# Altium schematic sheet RB_Conn.SchDoc(RB_Conn)
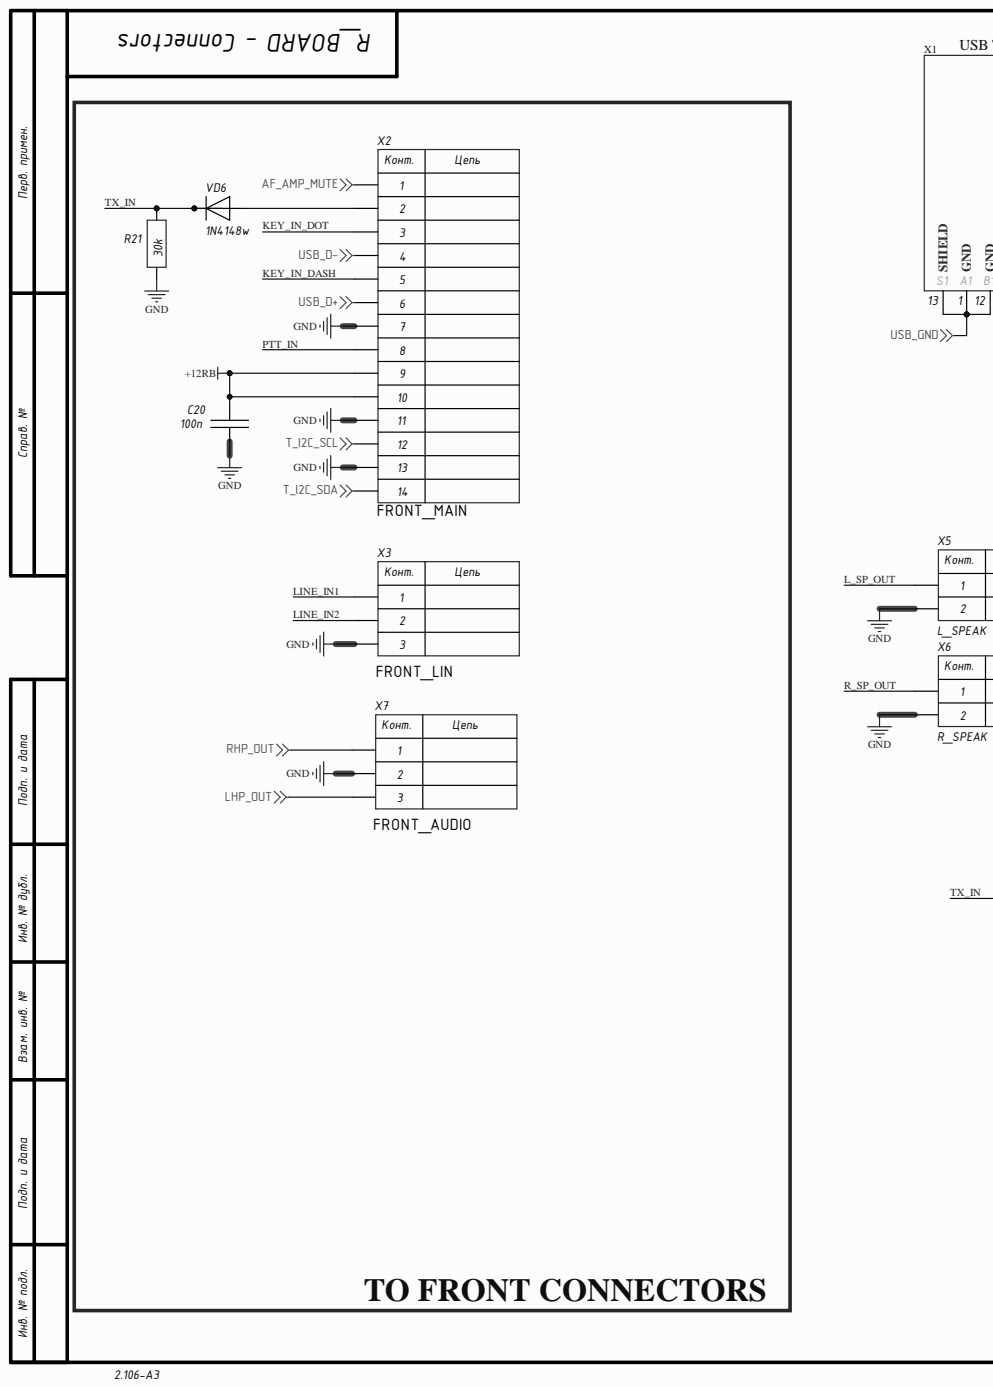
[diagram p0: RB_Conn.SchDoc, left]
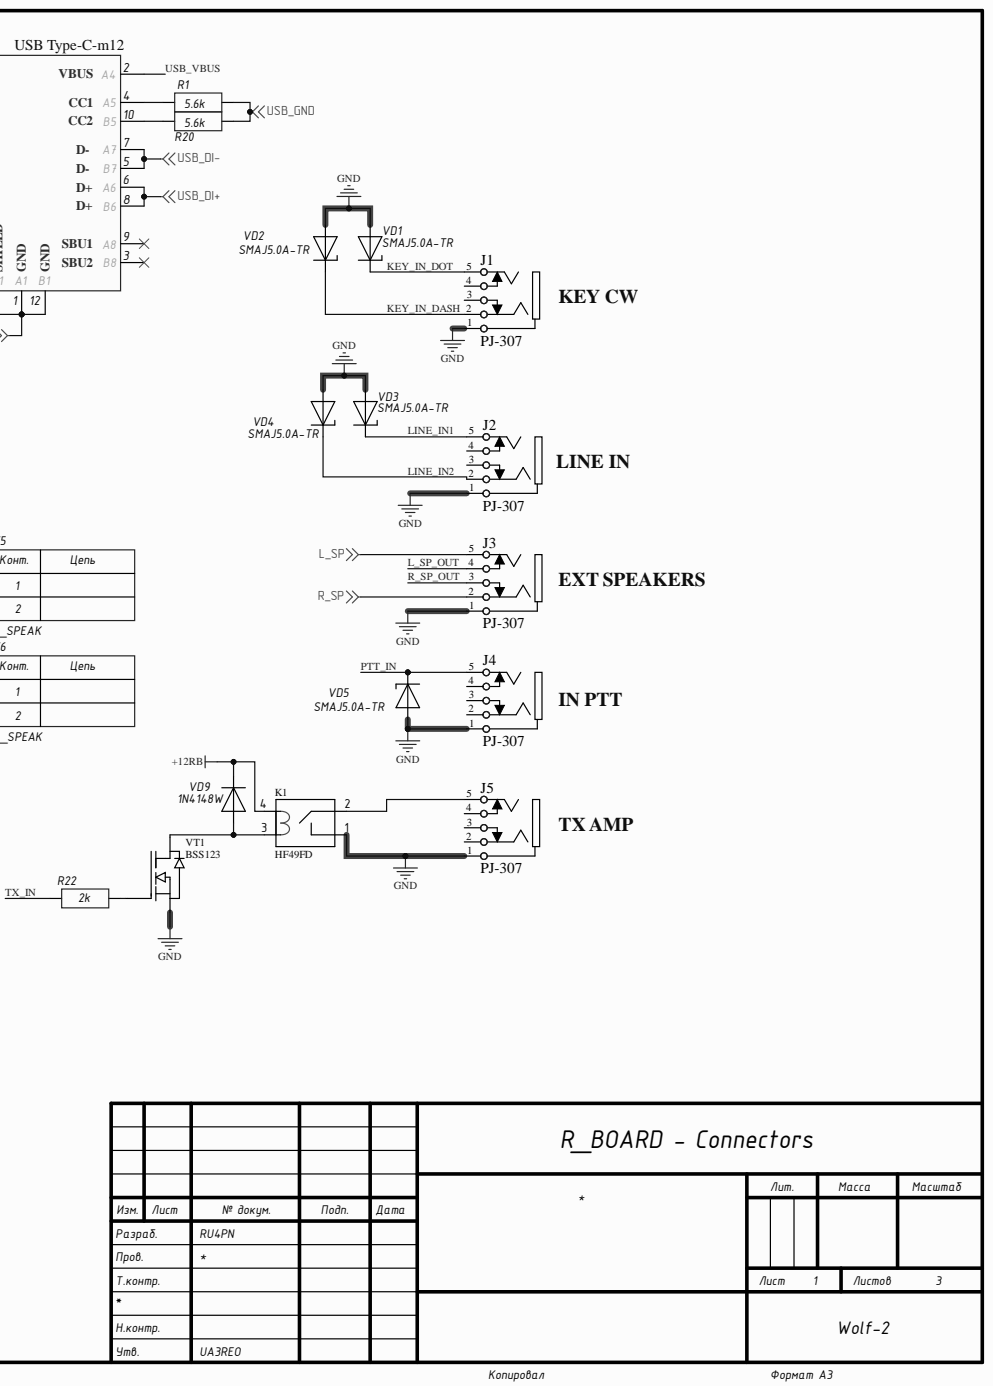
[diagram p1: RB_Conn.SchDoc, right]

## Parts
C20  100n  [CH0805C]
J1  PJ-307  [PJ-307]
J2  PJ-307  [PJ-307]
J3  PJ-307  [PJ-307]
J4  PJ-307  [PJ-307]
J5  PJ-307  [PJ-307]
K1  HF49FD  [HF49FD]
R1  5.6k  [CH0805R]
R20  5.6k  [CH0805R]
R21  30k  [CH0805R]
R22  2k  [CH0805R]
VD1  SMAJ5.0A-TR  [SMA]
VD2  SMAJ5.0A-TR  [SMA]
VD3  SMAJ5.0A-TR  [SMA]
VD4  SMAJ5.0A-TR  [SMA]
VD5  SMAJ5.0A-TR  [SMA]
VD6  1N4148w  [SOD323]
VD9  1N4148W  [SOD123]
VT1  BSS123  [SOT23_132]
X1  USB Type-C-m12  [PCBComponent_1]
X2  FRONT_MAIN  [CON: IDC-14MS]
X3  FRONT_LIN  [CON: CWF-3]
X5  L_SPEAK  [CON: PLS-2]
X6  R_SPEAK  [CON: PLS-2]
X7  FRONT_AUDIO  [CON: CWF-3]

## Nets
+12RB: C20.2 K1.4 VD9.2 X2.9 X2.10
AF_AMP_MUTE: X2.1
GND: C20.1 J1.1 J2.1 J3.1 J4.1 J5.1 K1.1 R21.1 VD1.1 VD2.1 VD3.1 VD4.1 VD5.1 VT1.2 X2.7 X2.11 X2.13 X3.3 X5.2 X6.2 X7.2
L_SP: J3.5
LHP_OUT: X7.3
R_SP: J3.2
RHP_OUT: X7.1
T_I2C_SCL: X2.12
T_I2C_SDA: X2.14
USB_D+: X2.6
USB_D-: X2.4
USB_DI+: X1.6(A6) X1.8(B6)
USB_DI-: X1.5(B7) X1.7(A7)
USB_GND: R1.2 R20.2 X1.1(A1_GND) X1.12(B1_GND) X1.13(S1_SHIELD)
KEY_IN_DASH: J1.2 VD2.2 X2.5
KEY_IN_DOT: J1.5 VD1.2 X2.3
L_SP_OUT: J3.4 X5.1
LINE_IN1: J2.5 VD3.2 X3.1
LINE_IN2: J2.2 VD4.2 X3.2
PTT_IN: J4.5 VD5.2 X2.8
R_SP_OUT: J3.3 X6.1
TX_IN: R21.2 R22.1 VD6.2
USB_VBUS: X1.2(A4)
NetJ5_5: J5.5 K1.2
NetK1_3: K1.3 VD9.1 VT1.3
NetR1_1: R1.1 X1.4(A5)
NetR20_1: R20.1 X1.10(B5)
NetR22_2: R22.2 VT1.1
NetVD6_1: VD6.1 X2.2

Not connected: J1 3 4; J2 3 4; J4 2 3 4; J5 2 3 4; X1 3(B8) 9(A8)

## Library data of the parts
C20 = Capacitor: Component Kind: Standard; Library Name: Discrete.SchLib
J1 = JACK_Audio-3: KEY; Component Kind: Standard; Library Name: Connectors.SchLib
J2 = JACK_Audio-3: LIN; Component Kind: Standard; Library Name: Connectors.SchLib
J3 = JACK_Audio-3: OUT_SPEAKERS; Component Kind: Standard; Library Name: Connectors.SchLib
J4 = JACK_Audio-3: PTT_IN; Component Kind: Standard; Library Name: Connectors.SchLib
J5 = JACK_Audio-3: TX; Component Kind: Standard; Library Name: Connectors.SchLib
K1 = HF49FD 012-1H12: Component Kind: Standard; Library Name: Relay.SchLib
R1 R20-R22 = Resistor: Component Kind: Standard; Library Name: Discrete.SchLib
VD1-VD5 = Diod Zener: Component Kind: Standard; Library Name: Diods.SchLib
VD6 VD9 = Diod: Component Kind: Standard; Library Name: Diods.SchLib
X1 = USB-C_2.0: Component Kind: Standard; Library Name: USB typ-c.SchLib
X2 = 14-Connector: Component Kind: Standard; Library Name: Connectors.SchLib
X3 X7 = 3-Connector: Component Kind: Standard; Library Name: Connectors.SchLib
X5 X6 = 2-Connector: Component Kind: Standard; Library Name: Connectors.SchLib
VT1 = BSS123: Component Kind: Standard; Library Name: Transistor.SchLib
